# Altium schematic sheet RocketShield_leds.SchDoc
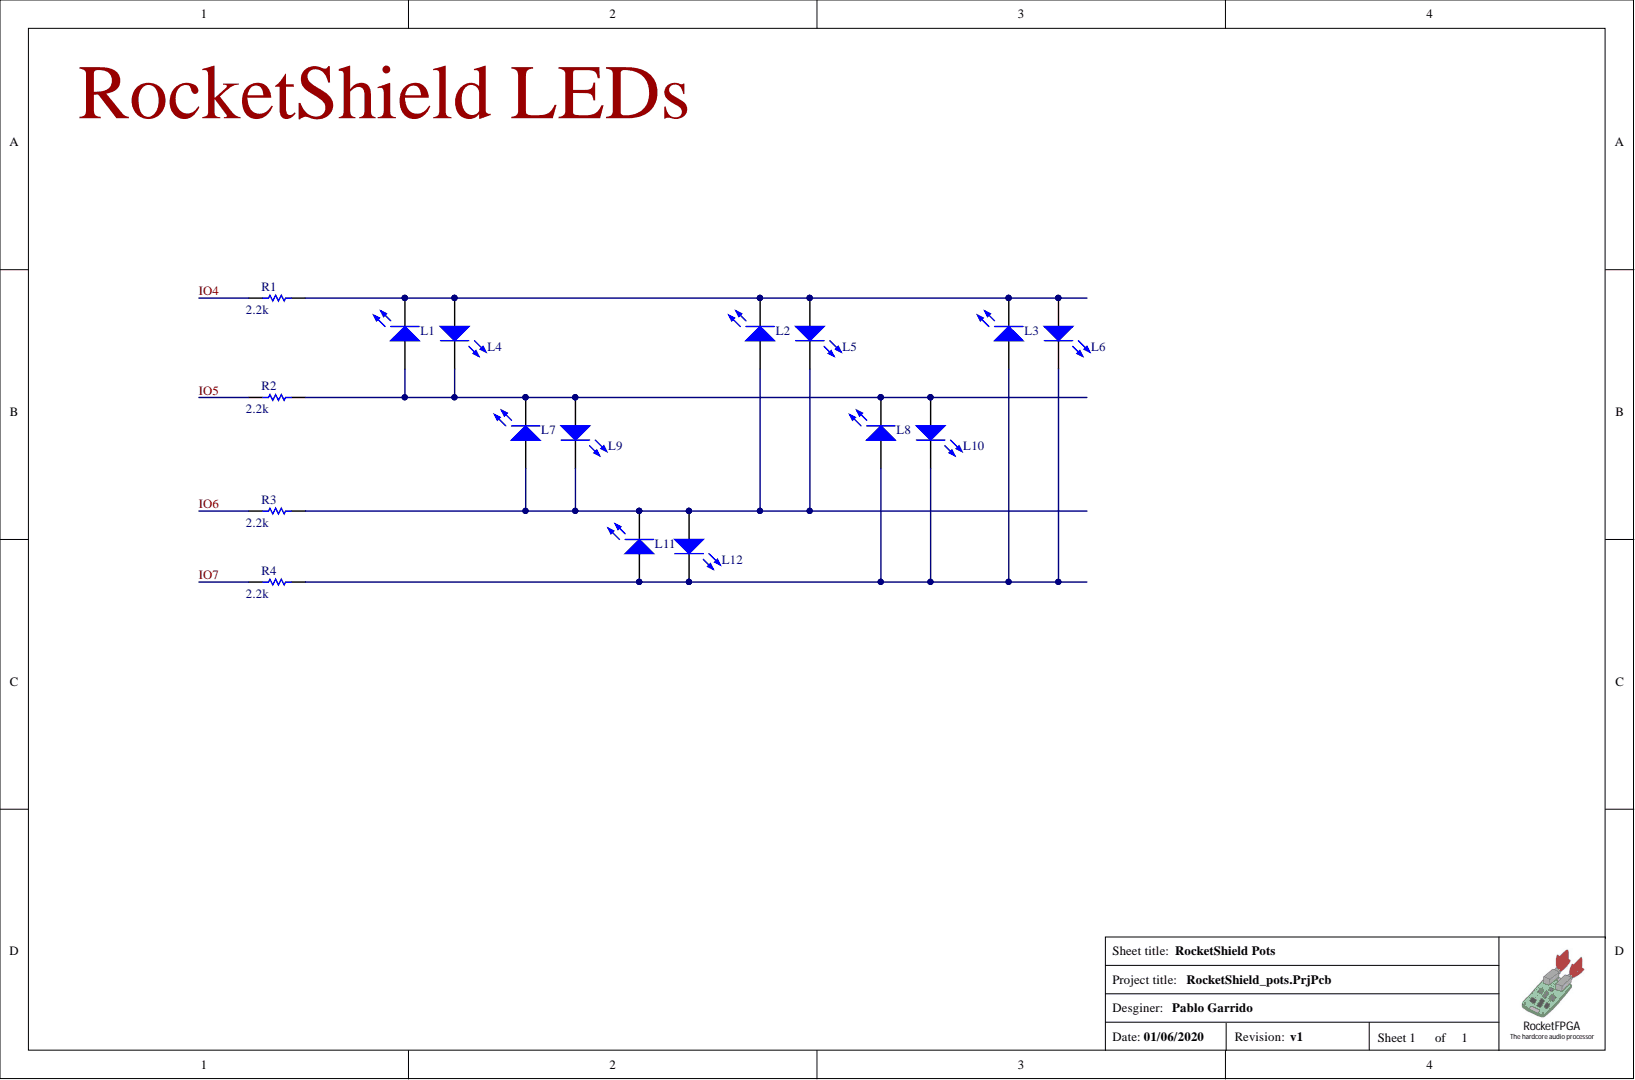
[diagram p0: RocketShield_leds.SchDoc]

## Parts
L1  LED_SMD  [0805]  (value not drawn)
L2  LED_SMD  [0805]  (value not drawn)
L3  LED_SMD  [0805]  (value not drawn)
L4  LED_SMD  [0805]  (value not drawn)
L5  LED_SMD  [0805]  (value not drawn)
L6  LED_SMD  [0805]  (value not drawn)
L7  LED_SMD  [0805]  (value not drawn)
L8  LED_SMD  [0805]  (value not drawn)
L9  LED_SMD  [0805]  (value not drawn)
L10  LED_SMD  [0805]  (value not drawn)
L11  LED_SMD  [0805]  (value not drawn)
L12  LED_SMD  [0805]  (value not drawn)
R1  value 2.2k  [0805]  (hidden comment: Resistor)
R2  value 2.2k  [0805]  (hidden comment: Resistor)
R3  value 2.2k  [0805]  (hidden comment: Resistor)
R4  value 2.2k  [0805]  (hidden comment: Resistor)

## Nets
IO4: R1.2
IO5: R2.2
IO6: R3.2
IO7: R4.2
NetL1_1: L1.1 L4.2 L7.2 L8.2 L9.1 L10.1 R2.1
NetL1_2: L1.2 L2.2 L3.2 L4.1 L5.1 L6.1 R1.1
NetL2_1: L2.1 L5.2 L7.1 L9.2 L11.2 L12.1 R3.1
NetL3_1: L3.1 L6.2 L8.1 L10.2 L11.1 L12.2 R4.1

## Library data of the parts
L1-L12 = LED_SMD: LED SMD; Vendor: LCSC; Component Kind: Standard; Link: https://lcsc.com/product-detail/Light-Emitting-Diodes-LED_0805-Red-LED-Iv-61mcd-Typ-atIF-20mA_C72037.html; Library Name: RocketShield_pots.SchLib
R1-R4 = Resistor: Component Kind: Standard; Description: Resistor; Signal Integrity: Res; Simulation: RESISTOR; Library Name: RocketShield_pots.SchLib
